# Altium schematic sheet V853_FLASH_eMMC.SchDoc(V853_FLASH_eMMC)
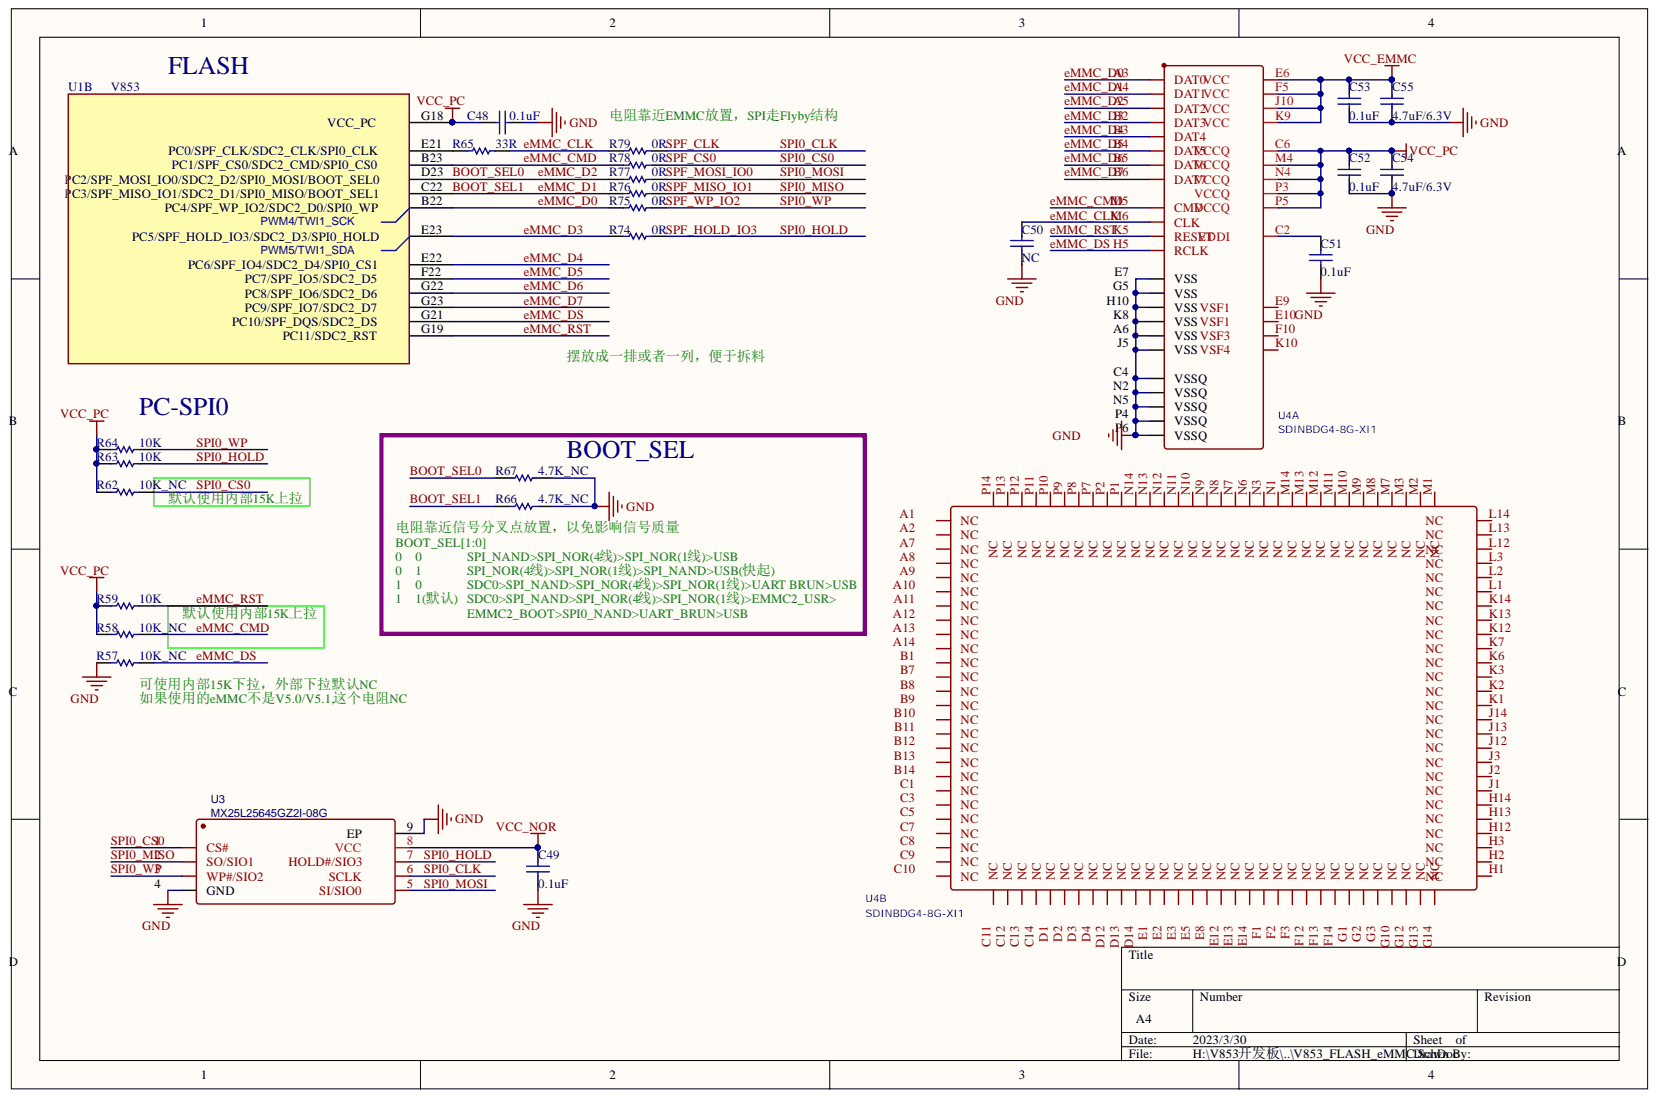
[diagram p0: V853_FLASH_eMMC.SchDoc]

## Parts
C48  0.1uF  [C0201]
C49  0.1uF  [C0201]
C50  NC  [C0201]
C51  0.1uF  [C0201]
C52  0.1uF  [C0201]
C53  0.1uF  [C0201]
C54  4.7uF/6.3V  [0402]
C55  4.7uF/6.3V  [0402]
R57  10K_NC  [R0201]
R58  10K_NC  [R0201]
R59  10K  [R0201]
R62  10K_NC  [R0201]
R63  10K  [R0201]
R64  10K  [R0201]
R65  33R  [R0201]
R66  4.7K_NC  [R0201]
R67  4.7K_NC  [R0201]
R74  0R  [R0201]
R75  0R  [R0201]
R76  0R  [R0201]
R77  0R  [R0201]
R78  0R  [R0201]
R79  0R  [R0201]
U1  V853  (part B)
U3  MX25L25645GZ2I-08G  [WSON-8_L6.0-W8.0-P1.27-BL-EP]
U4  SDINBDG4-8G-XI1  [FBGA-153_L13.0-W11.5-P0.50_KLMAG1JETD-B041-simpified]  (parts A,B)

## Nets
GND: C48.1 C49.1 C50.1 C51.1 C52.1 C53.1 C54.1 C55.1 R57.2 R66.1 R67.1 U3.4(GND) U3.9 U4.A6 U4.C4(VSSQ) U4.E7(VSS) U4.G5(VSS) U4.H10 U4.J5 U4.K8 U4.N2(VSSQ) U4.N5(VSSQ) U4.P4(VSSQ) U4.P6(VSSQ)
VCC_EMMC: C53.2 C55.2 U4.E6 U4.F5 U4.J10 U4.K9
VCC_NOR: C49.2 U3.8
VCC_PC: C48.2 C52.2 C54.2 R58.2 R59.2 R62.2 R63.2 R64.2 U1.G18 U4.C6 U4.M4 U4.N4 U4.P3 U4.P5
BOOT_SEL0: R67.2 R77.2 U1.D23 U4.A5(DAT2)
BOOT_SEL1: R66.2 R76.2 U1.C22 U4.A4(DAT1)
EMMC_CLK: C50.2 R65.1 R79.2 U4.M6(CLK)
EMMC_CMD: R58.1 R78.2 U1.B23 U4.M5(CMD)
EMMC_D0: R75.2 U1.B22 U4.A3(DAT0)
EMMC_D3: R74.2 U1.E23 U4.B2(DAT3)
EMMC_D4: U1.E22 U4.B3(DAT4)
EMMC_D5: U1.F22 U4.B4(DAT5)
EMMC_D6: U1.G22 U4.B5(DAT6)
EMMC_D7: U1.G23 U4.B6(DAT7)
EMMC_DS: R57.1 U1.G21 U4.H5(RCLK)
EMMC_RST: R59.1 U1.G19 U4.K5(RESET)
SPF_CLK: R79.1 U3.6
SPF_CS0: R62.1 R78.1 U3.1(CS#)
SPF_HOLD_IO3: R63.1 R74.1 U3.7
SPF_MISO_IO1: R76.1 U3.2(SO/SIO1)
SPF_MOSI_IO0: R77.1 U3.5
SPF_WP_IO2: R64.1 R75.1 U3.3(WP#/SIO2)
NetC51_2: C51.2 U4.C2
NetR65_2: R65.2 U1.E21

Not connected: U4 A1(NC) A2(NC) A7(NC) A8(NC) A9(NC) A10(NC) A11(NC) A12(NC) A13(NC) A14(NC) B1(NC) B7(NC) B8(NC) B9(NC) B10(NC) B11(NC) B12(NC) B13(NC) B14(NC) C1(NC) C3(NC) C5(NC) C7(NC) C8(NC) C9(NC) C10(NC) C11(NC) C12(NC) C13(NC) C14(NC) D1(NC) D2(NC) D3(NC) D4(NC) D12(NC) D13(NC) D14(NC) E1(NC) E2(NC) E3(NC) E5(NC) E8(NC) E9 E10 E12(NC) E13(NC) E14(NC) F1(NC) F2(NC) F3(NC) F10 F12(NC) F13(NC) F14(NC) G1(NC) G2(NC) G3(NC) G10(NC) G12(NC) G13(NC) G14(NC) H1 H2 H3 H12 H13 H14 J1 J2 J3 J12 J13 J14 K1 K2 K3 K6 K7 K10 K12 K13 K14 L1 L2 L3 L12 L13 L14 M1(NC_NC) M2 M3 M7 M8 M9 M10 M11 M12 M13 M14 N1 N3 N6 N7 N8 N9 N10 N11 N12 N13 N14 P1 P2 P7 P8 P9 P10 P11 P12 P13 P14

## Library data of the parts
C48-C55 = Cap: Capacitor; PartNumber: CAP100NF; Component Kind: Standard; LatestRevisionDate: 17-Jul-2002; LatestRevisionNote: Re-released for DXP Platform.; Library Name: Miscellaneous Devices.IntLib; PackageReference: 0402; Published: 8-Jun-2000; Signal Integrity: Cap; Simulation: CAP; Publisher: Altium Limited
  C48 C49 C51-C53: Value: 0.1uF
  C50: Value: NC
  C54 C55: Value: 4.7uF/6.3V
R57-R59 R62-R67 R74-R79 = Res1: Resistor; PartNumber: RES2.2K; Component Kind: Standard; LatestRevisionDate: 17-Jul-2002; LatestRevisionNote: Re-released for DXP Platform.; Library Name: Miscellaneous Devices.IntLib; PackageReference: 0402; Published: 8-Jun-2000; Signal Integrity: Res; Simulation: RESISTOR; Publisher: Altium Limited
  R57 R58 R62: Value: 10K_NC
  R59 R63 R64: Value: 10K
  R65: Value: 33R
  R66 R67: Value: 4.7K_NC
  R74-R79: Value: 0R
U1 = V853_0621: PCB Footprint: BGA318P50B1200_1200H113; SPLIT_INST: TRUE; SWAP_INFO: (S1+S2+S3+S4+S5+S6+S7+S8+S9); Component Kind: Standard; Library Name: V853_CORE_20230224.SCHLIB
U3 = MX35LF1GE4AB-Z4I_C402160: BOM_Manufacturer: Macronix; Contributor: LCSC; BOM_JLCPCB Part Class: Extended Part; BOM_Manufacturer Part: MX35LF1GE4AB-Z4I; BOM_Supplier: LCSC; BOM_Supplier Part: C2802978; Component Kind: Standard; spicePre: U; spiceSymbolName: MX25L25645GZ2I-08G
U4 = SDINBDG4-8G-XI1: Component Kind: Standard; Library Name: HM2.SchLib
